# Altium schematic sheet CW460.SchDoc
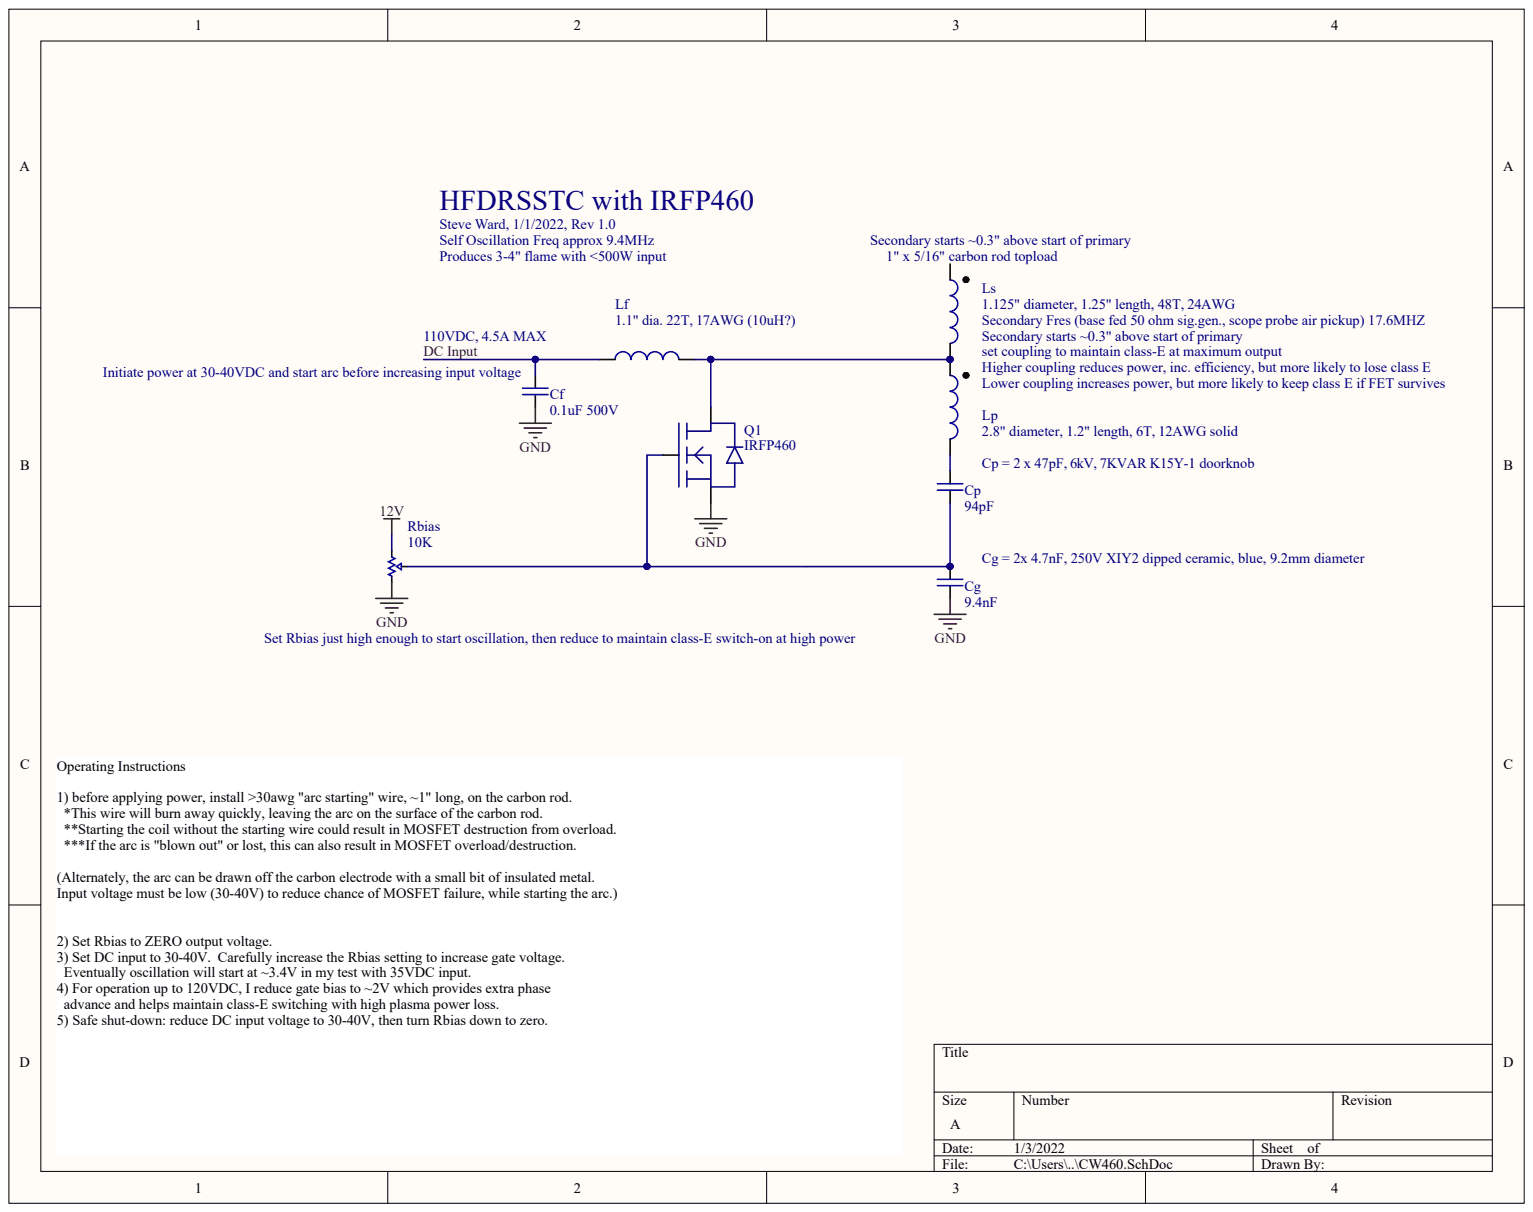
[diagram p0: CW460.SchDoc]

## Parts
Cf  0.1uF 500V
Cg  9.4nF
Cp  94pF
Lf  1.1" dia. 22T, 17AWG (10uH?)
Lp  2.8" diameter, 1.2" length, 6T, 12AWG solid
Ls  1.125" diameter, 1.25" length, 48T, 24AWG
Q1  IRFP460
Rbias  value 10K  (hidden comment: 72-T93YA-10K)

## Nets
12V: Rbias.3
GND: Cf.1 Cg.1 Q1.3 Rbias.1
DC INPUT: Cf.2 Lf.1
NetCg_2: Cg.2 Cp.1 Q1.1 Rbias.2
NetCp_2: Cp.2 Lp.2
NetLf_2: Lf.2 Lp.1 Ls.2 Q1.2

Not connected: Ls 1
